# Altium schematic sheet EC25.SchDoc(EC25)
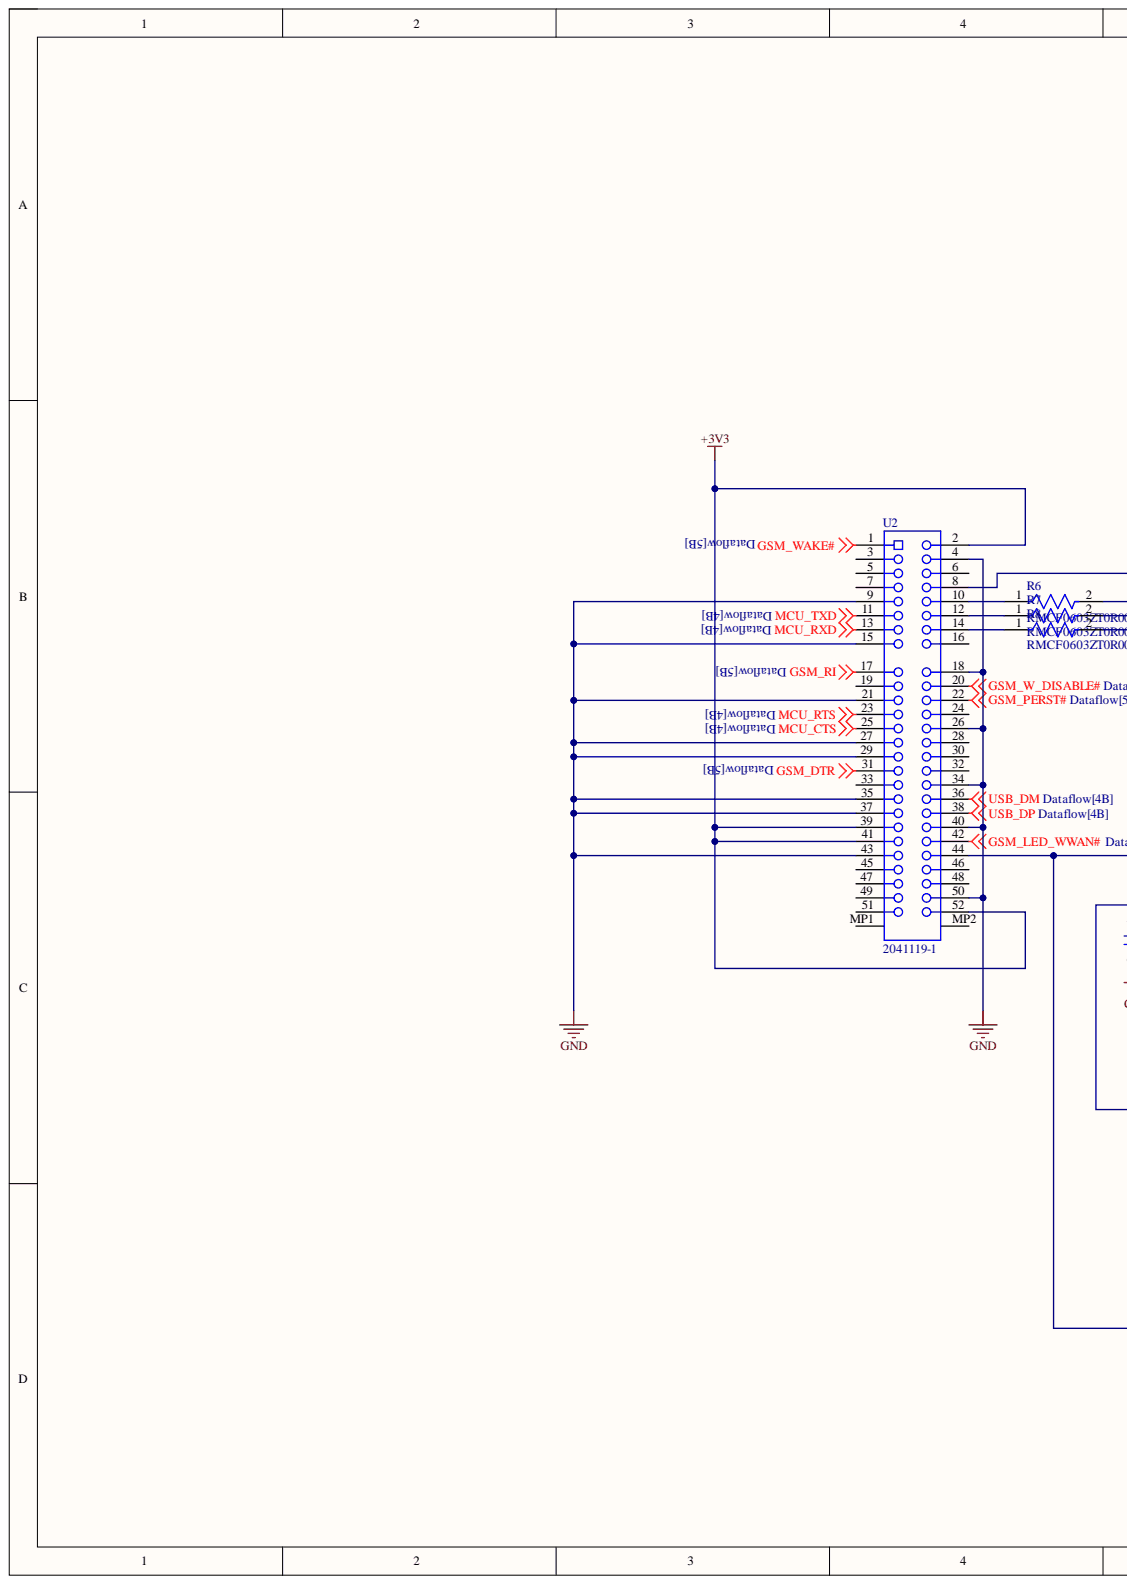
[diagram p0: EC25.SchDoc, left]
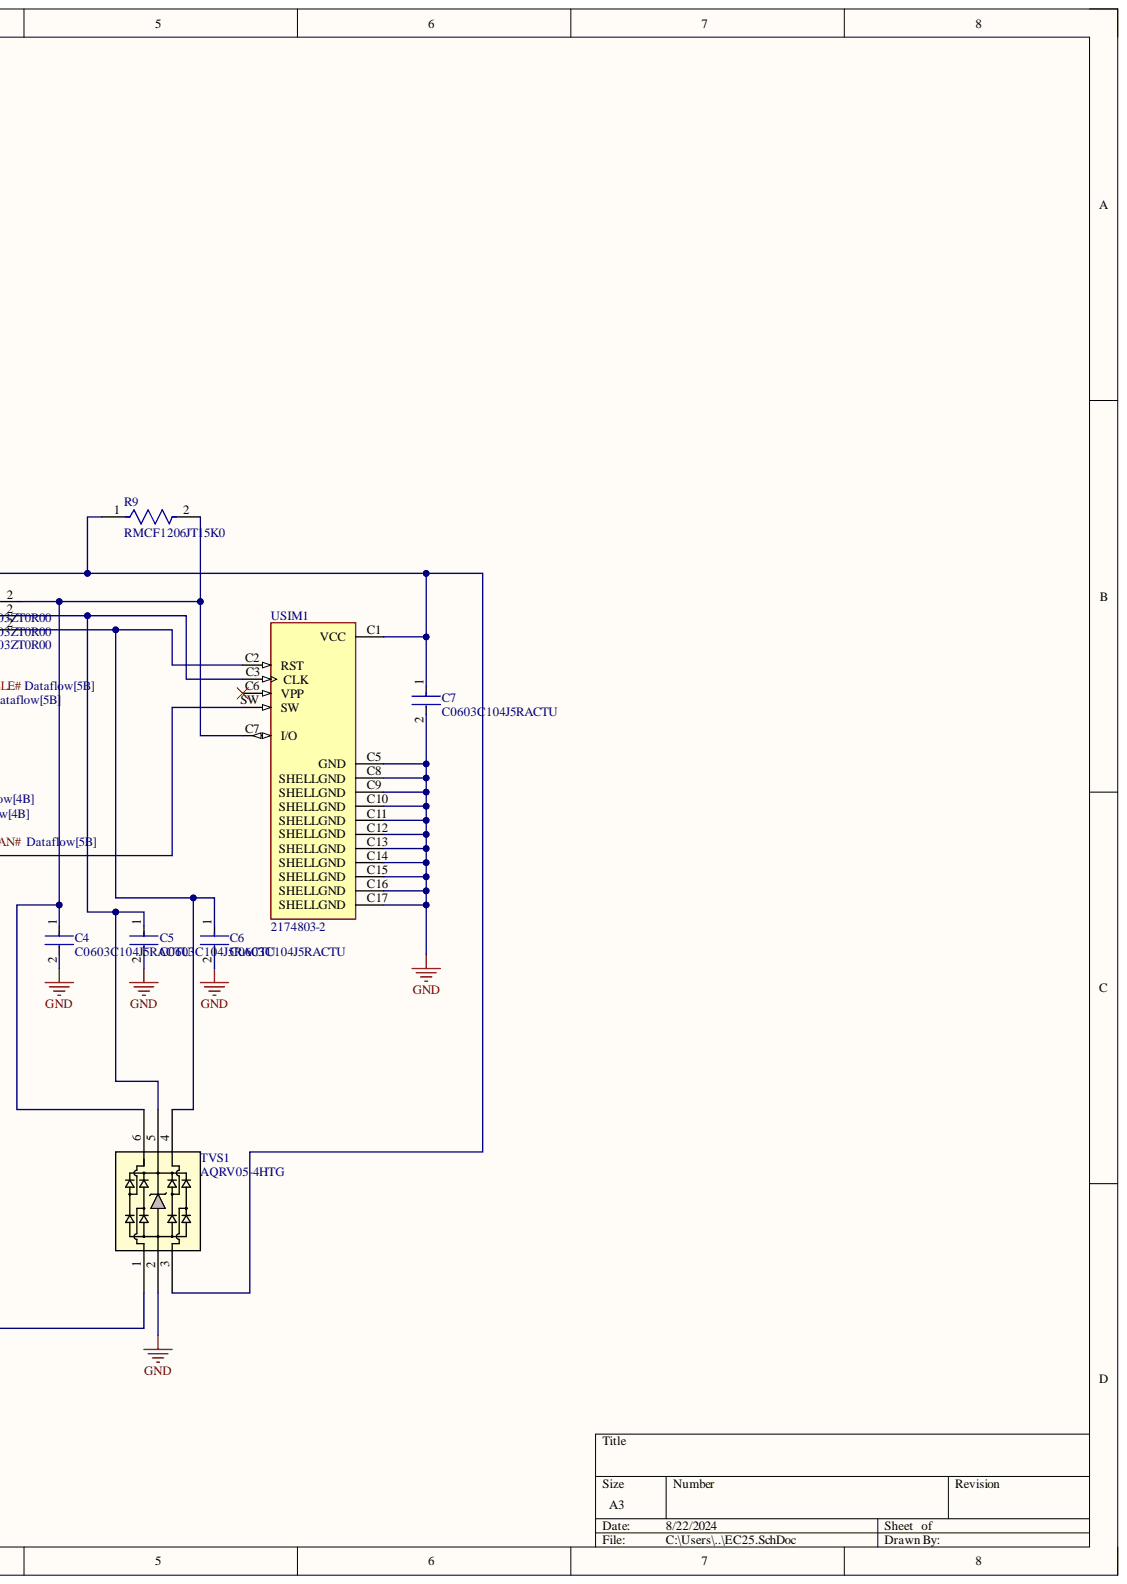
[diagram p1: EC25.SchDoc, right]

## Parts
C4  C0603C104J5RACTU  [FP-C0603C-CF-MFG]
C5  C0603C104J5RACTU  [FP-C0603C-CF-MFG]
C6  C0603C104J5RACTU  [FP-C0603C-CF-MFG]
C7  C0603C104J5RACTU  [FP-C0603C-CF-MFG]
R6  RMCF0603ZT0R00  [FP-RMCF0603-MFG]
R7  RMCF0603ZT0R00  [FP-RMCF0603-MFG]
R8  RMCF0603ZT0R00  [FP-RMCF0603-MFG]
R9  RMCF1206JT15K0  [FP-RMCF1206-IPC_A]
TVS1  AQRV05-4HTG  [SOT23-6_LTF]
U2  2041119-1  [TECO-2041119-1_3_V]
USIM1  2174803-2  [CONN_2174803-2]

## Nets
+3V3: U2.2 U2.39 U2.41 U2.52
GND: C4.2 C5.2 C6.2 C7.2 TVS1.2 U2.4 U2.9 U2.15 U2.18 U2.21 U2.26 U2.27 U2.29 U2.34 U2.35 U2.37 U2.40 U2.43 U2.50 USIM1.C5(GND) USIM1.C8(SHELLGND) USIM1.C9(SHELLGND) USIM1.C10(SHELLGND) USIM1.C11(SHELLGND) USIM1.C12(SHELLGND) USIM1.C13(SHELLGND) USIM1.C14(SHELLGND) USIM1.C15(SHELLGND) USIM1.C16(SHELLGND) USIM1.C17(SHELLGND)
GSM_DTR: U2.31
GSM_LED_WWAN#: U2.42
GSM_PERST#: U2.22
GSM_RI: U2.17
GSM_W_DISABLE#: U2.20
GSM_WAKE#: U2.1
MCU_CTS: U2.25
MCU_RTS: U2.23
MCU_RXD: U2.13
MCU_TXD: U2.11
USB_DM: U2.36
USB_DP: U2.38
NetC4_1: C4.1 R6.2 R9.2 TVS1.6 USIM1.C7(I/O)
NetC5_1: C5.1 R7.2 TVS1.5 USIM1.C3(CLK)
NetC6_1: C6.1 R8.2 TVS1.4 USIM1.C2(RST)
NetC7_1: C7.1 R9.1 TVS1.3 U2.8 USIM1.C1(VCC)
NetR6_1: R6.1 U2.10
NetR7_1: R7.1 U2.12
NetR8_1: R8.1 U2.14
NetTVS1_1: TVS1.1 U2.44 USIM1.SW

Not connected: U2 3 5 6 7 16 19 24 28 30 32 33 45 46 47 48 49 51 MP1 MP2; USIM1 C6(VPP)

## Library data of the parts
C4-C7 = CMP-2006-03056-2: CAP CER 0.1UF 50V 5% X7R 0603; Manufacturer: KEMET; Automotive Grade: Grade 1; Capacitance: 0.10 μF; Material: Ceramic; Temperature Coefficient: 30ppm/°C; Tolerance: 0.05; Value: 0.10 μF; Automotive: Yes; Category: Cap; Component Kind: Standard; ComponentLink2Description: Datasheet; ComponentLink2URL: https://api.kemet.com/component-edge/download/datasheet/C0603C100J5GACAUTO.pdf; Device Class L1: Passive Components; Device Class L2: Capacitors; Device Class L3: Ceramic Capacitors; Digikey Description: CAP CER 0.1UF 50V 5% X7R 0603; Height: 0.87mm; Lead Free: Yes; Library Name: Altium Content Vault; Manufacturer Part Number: C0603C104J5RACTU; Mouser Description: Multilayer Ceramic Capacitors MLCC - SMD/SMT 50volts 0.1uF X7R 5%; Octopart: https://octopart.com/c0603c104j5ractu-kemet-10440638; Package: C0603C-CF; RoHS: TRUE; Temperature: -55°C to +125°C; Temperature Characteristic: X7R; Temperature Range High: +125°C; Temperature Range Low: -55°C; Voltage Rating: 50 V
R6-R8 = CMP-26527-000011-1: RES 0 OHM JUMPER 1/10W 0603; Manufacturer: Stackpole; Automotive Grade: Grade 0; Power Rating: 0.1W; Resistance: 0mΩ; Value: 0mΩ; Voltage Rating: 75V; Automotive: Yes; Category: Res; Component Kind: Standard; ComponentLink2Description: Datasheet; ComponentLink2URL: https://www.seielect.com/catalog/sei-rmcf_rmcp.pdf; Composition: Thick Film; Device Class L1: Passive Components; Device Class L2: Resistors; Device Class L3: Chip SMD Resistors; Digikey Description: RES 0 OHM JUMPER 1/10W 0603; Height: 0.55mm; Ipc Land Pattern Name: RESC15580X45; Lead Free: Yes; Library Name: Altium Content Vault; Manufacturer Part Number: RMCF0603ZT0R00; Octopart: https://octopart.com/rmcf0603zt0r00-stackpole+electronics-19213618; Package: RMCF0603; RoHS: TRUE; Series: RMCF; Temperature: -55°C to +155°C; Temperature Range High: +155°C; Temperature Range Low: -55°C
R9 = CMP-26527-001400-1: 15kΩ ±5% 0.25W 1206 Thick Film Chip Resistor AEC-Q200 compliant; Max Power Dissipation: 250mW; Resistance: 15kR; Temperature Coefficient: 200ppm/°C; Case Code (Imperial): 1206; Case Code (Metric): 3216; Case/Package: 1206; Component Kind: Standard; Construction: Rectangular; Contact Plating: Tin; Depth: 1.6mm; Features: Automotive AEC-Q200; Height: 0.028inch; Lead Free: Lead Free; Length: 0.126inch; Library Name: Altium Content Vault; Max Operating Temperature: 155°C; Military Standard: Not; Min Operating Temperature: -55°C; Mount: Surface Mount; Number of Terminations: 2; Packaging: Tape and Reel; Power Rating: 250mW; Radiation Hardening: No; Resistor Type: Thick Film; RoHS Compliant: Yes; Series: RMCF; Size Code: 1206; Tolerance: 5%; Width: 0.063inch
TVS1 = AQRV05-4HTG: No Description Available; Mfr_Name: Littelfuse Inc; Component Kind: Standard; Library Name: AQRV05-4HTG.SchLib; RefDes: RefDes; Type: Type
U2 = CMP-2011-00000-2: mSATA & PCI Express Mini Card Connector, Pitch 0.4 mm, 52 Position, Height 4 mm, RoHS, Tape and Reel; Manufacturer: TE Connectivity; Case\Package: SMD; Contacts: 52; Rows: 2; Component Kind: Standard; Contact Material: Copper; Contact Plating: Gold; Datasheet URL: http://www.te.com/commerce/DocumentDelivery/DDEController?Action=showdoc&DocId=Customer+Drawing%7F2041119%7FD%7Fpdf%7FEnglish%7FENG_CD_2041119_D.pdf%7F2041119-1; Datasheet Version: 06/2016; ELV: Compliant; Height: 4mm; Housing Colour: Black; Housing Material: Polymer; Length: 29.9mm; Library Name: Altium Content Vault; Manufacturer URL: http://www.te.com/; Mount: SurfaceMount; Mounting Technology: SurfaceMount; Orientation: RightAngle; Package Description: mSATA & PCI Express Mini Card Connector, Pitch 0.4 mm, 52 Position, Height 4 mm; Package Reference: 2041119-1_3; Packaging: TapeandReel; Poles: 52; Radiation Hardening: No; RoHSCompliant: true; Termination: Solder; Width: 9.02mm
USIM1 = 2174803-2: CONNECTOR, SIM SOCKET, 6POS, 2.54MM; Connector Type:SIM Socket; Series:2174803;; Centerline_Pitch: 2.54 mm[.1 in]; MF: TE Connectivity; Number_of_Positions: 6; Voltage_Rating: 10 VAC; Availability: Unavailable; Component Kind: Standard; EU_RoHS_Compliance: Compliant; Library Name: 2174803-2.IntLib; MP: 2174803-2; Package: None; Price: None; Product_Type: Connector; SnapEDA_Link: https://www.snapeda.com/parts/2174803-2/TE%20Connectivity%20AMP%20Connectors/view-part/47676/?ref=snap; TE_purchase_URL: https://www.te.com/usa-en/product-2174803-2.html?te_bu=Cor&te_type=disp&te_campaign=seda_glo_cor-seda-global-disp-prtnr-fy19-seda-model-bom-cta_sma-317_1&elqCampaignId=32493
